# Altium schematic sheet main.SchDoc
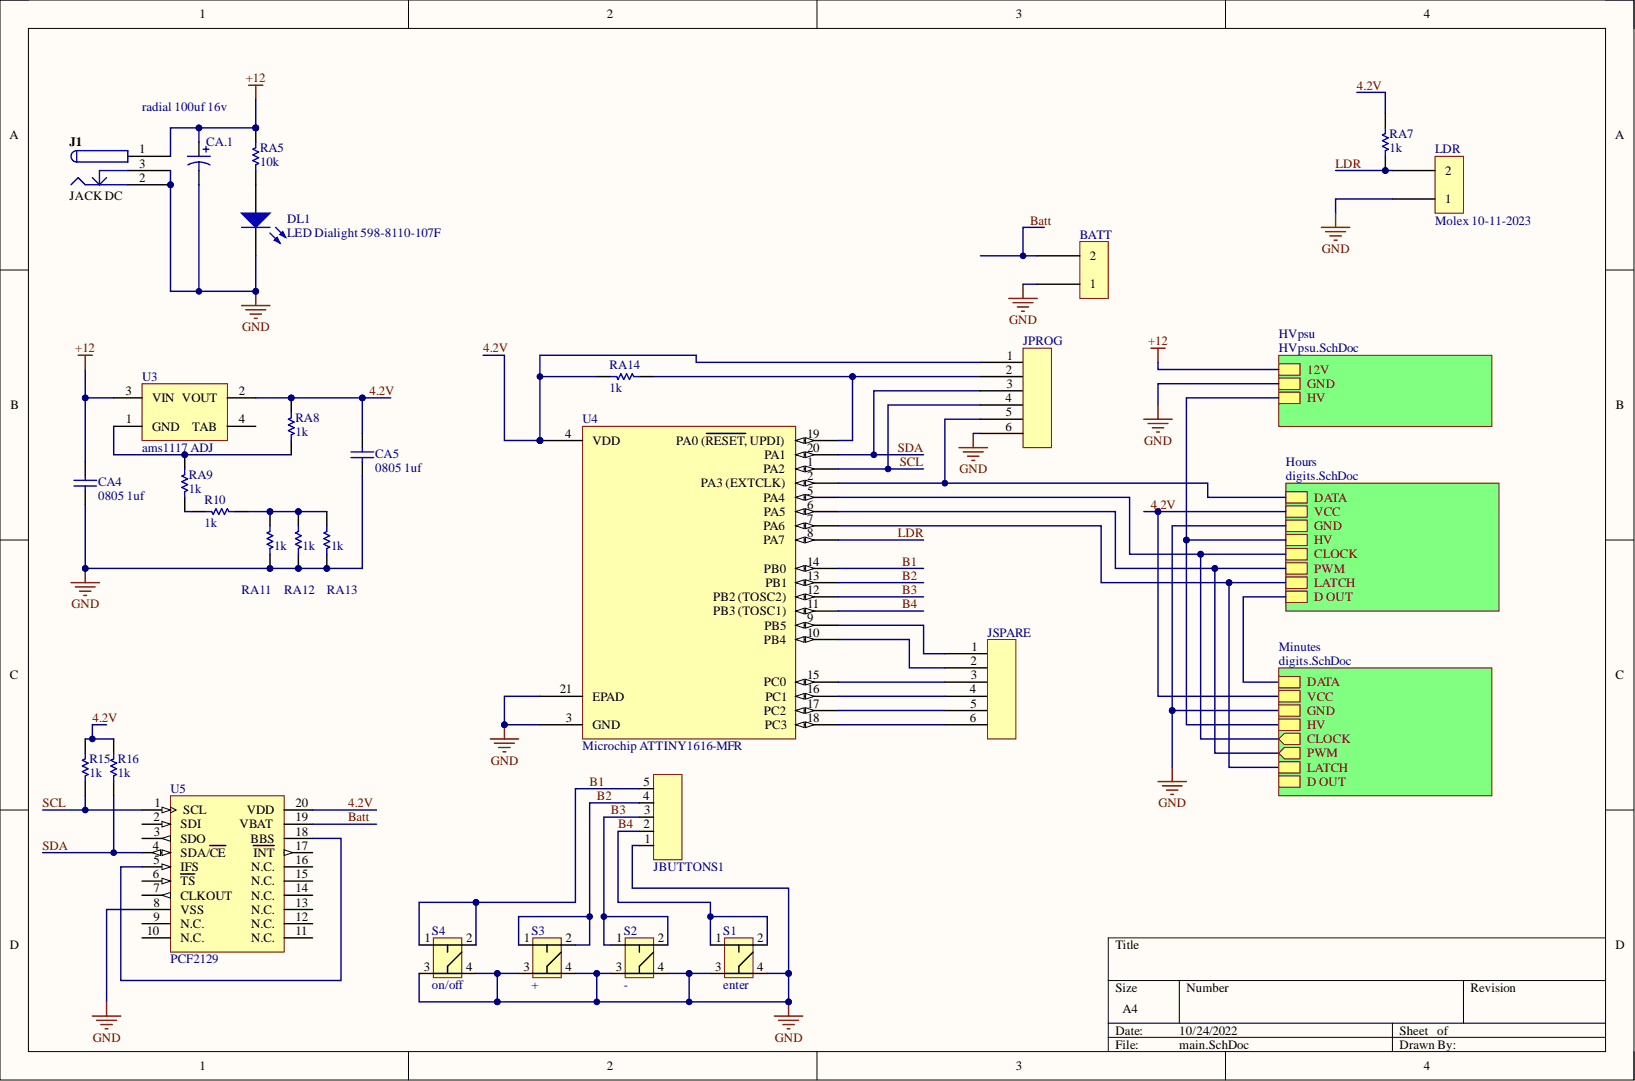
[diagram p0: main.SchDoc]

## Parts
BATT  Molex 10-11-2023  [PCB-hfmt5autxu6v8tocc2lw-1]
CA4  0805 1uf  Case/Package: 0805  [CAPC2013X140X50NL20T25]
CA5  0805 1uf  Case/Package: 0805  [CAPC2013X140X50NL20T25]
CA.1  radial 100uf 16v  [Capacitor]
DL1  LED Dialight 598-8110-107F  [PCB-9ifma0oh53wy532w7hco-1]
J1  JACK DC  [PCB-JACK-POWER]
JBUTTONS1  90147-1105  [PCB-igo8iybgws4dk1r37smx-1]  (value not drawn)
JPROG  Molex 70543-0005  [PCB-75wdbon8e5tdv2j637ia-1]  (value not drawn)
JSPARE  Molex 70543-0005  [PCB-75wdbon8e5tdv2j637ia-1]  (value not drawn)
LDR  Molex 10-11-2023  [PCB-hfmt5autxu6v8tocc2lw-1]
R10  1k  [PCB-hsw2o4davxo7exkzigz5-1]
R15  1k  [PCB-hsw2o4davxo7exkzigz5-1]
R16  1k  [PCB-hsw2o4davxo7exkzigz5-1]
RA5  10k  [PCB-hsw2o4davxo7exkzigz5-1]
RA7  1k  [PCB-hsw2o4davxo7exkzigz5-1]
RA8  1k  [PCB-hsw2o4davxo7exkzigz5-1]
RA9  1k  [PCB-hsw2o4davxo7exkzigz5-1]
RA11  1k  [PCB-hsw2o4davxo7exkzigz5-1]
RA12  1k  [PCB-hsw2o4davxo7exkzigz5-1]
RA13  1k  [PCB-hsw2o4davxo7exkzigz5-1]
RA14  1k  [PCB-hsw2o4davxo7exkzigz5-1]
S1  enter  [PCB-dfixreegix1guex256v0-1]
S2  -  [PCB-dfixreegix1guex256v0-1]
S3  +  [PCB-dfixreegix1guex256v0-1]
S4  on/off  [PCB-dfixreegix1guex256v0-1]
U3  ams1117 ADJ  [SOT-223_D]
U4  Microchip ATTINY1616-MFR  [PCB-l0aji733vjrxxdnx6zin-1]
U5  PCF2129  [SO20_N]

## Nets
+12: CA4.2 CA.1.1 J1.1 RA5.2 U3.3(VIN)
GND: BATT.1 CA4.1 CA5.1 CA.1.2 DL1.1 J1.2 J1.3 JBUTTONS1.1 JPROG.6 LDR.1 RA11.2 RA12.2 RA13.2 S1.3 S1.4 S2.3 S2.4 S3.3 S3.4 S4.3 S4.4 U4.3(GND) U4.21(EPAD) U5.8(VSS)
4.2V: CA5.2 JPROG.1 R15.2 R16.2 RA7.2 RA8.1 RA14.1 U3.2(VOUT) U4.4(VDD) U5.20(VDD)
B1: JBUTTONS1.5 S4.1 S4.2 U4.14(PB0)
B2: JBUTTONS1.4 S3.1 S3.2 U4.13(PB1)
B3: JBUTTONS1.3 S2.1 S2.2 U4.12(PB2_(TOSC2))
B4: JBUTTONS1.2 S1.1 S1.2 U4.11(PB3_(TOSC1))
Batt: BATT.2 U5.19(VBAT)
LDR: LDR.2 RA7.1 U4.8(PA7)
SCL: JPROG.4 R15.1 U4.1(PA2) U5.1(SCL)
SDA: JPROG.3 R16.1 U4.20(PA1) U5.4(SDA/CE)
NetDL1_2: DL1.2 RA5.1
NetJPROG_2: JPROG.2 RA14.2 U4.19(PA0_(RESET,_UPDI))
NetJPROG_5: JPROG.5 U4.2(PA3_(EXTCLK))
NetJSPARE_1: JSPARE.1 U4.9(PB5)
NetJSPARE_2: JSPARE.2 U4.10(PB4)
NetJSPARE_3: JSPARE.3 U4.15(PC0)
NetJSPARE_4: JSPARE.4 U4.16(PC1)
NetJSPARE_5: JSPARE.5 U4.17(PC2)
NetJSPARE_6: JSPARE.6 U4.18(PC3)
NetR10_1: R10.1 RA11.1 RA12.1 RA13.1
NetR10_2: R10.2 RA9.1
NetRA8_2: RA8.2 RA9.2 U3.1(GND)
NetU3_4: U3.4(TAB)
NetU4_5: U4.5(PA4)
NetU4_6: U4.6(PA5)
NetU4_7: U4.7(PA6)
NetU5_2: U5.2(SDI)
NetU5_3: U5.3(SDO)
NetU5_5: U5.5(IFS) U5.18(BBS)
NetU5_6: U5.6(TS)
NetU5_7: U5.7(CLKOUT)
NetU5_9: U5.9(N.C.)
NetU5_10: U5.10(N.C.)
NetU5_11: U5.11(N.C.)
NetU5_12: U5.12(N.C.)
NetU5_13: U5.13(N.C.)
NetU5_14: U5.14(N.C.)
NetU5_15: U5.15(N.C.)
NetU5_16: U5.16(N.C.)
NetU5_17: U5.17(INT)
D OUT:
HV:

## Library data of the parts
BATT LDR = 10-11-2023: 2.54mm (.100") Pitch KK® Crimp Terminal Housing, High Pressure, 2 Circuits; Component Kind: Standard; Library Name: Octopart library
CA4 CA5 = CMP-127269-2: CAP CER 1UF 10V 10% X7R 0805; Manufacturer Part Number: 0805ZC105KAZ2A; Capacitance: 1e-06 F; Case/Package (SI): 2012; Dielectric Material: Ceramic Multilayer; Lead-Free Status: Lead Free; Voltage Rating (DC): 10.0 V; Capacitance Tolerance: ±10%; Component Kind: Standard; Dielectric Characteristic: X7R; Library Name: CommunityVault; Mounting Style: Surface Mount; Number of Pins: 2; Octopart Url: https://octopart.com/0805zc105kaz2a-avx+interconnect+%2F+elco-195627; OctopartID: b6f47ffc6fb96933; REACH SVHC Compliance: No SVHC; RoHS: Compliant; Size-Height: 0.00094 m; Size-Length: 0.00201 m; Size-Width: 0.00125 m
CA.1 = ECA-1CM101: Cap Aluminum 100uF 16V 20% (5 X 11mm) Radial Aluminum Cylindrical Can 2mm 180mA 2000 hr 85°C Bulk; Voltage Rating (DC): 16.0 V; Component Kind: Standard; Library Name: Octopart library; Octopart URL: http://octopart.com/eca1cm101-panasonic-2628787; OctopartID: 48ba8198716f977d; Packaging: Bulk; REACH SVHC Compliance: No SVHC; Size-Diameter: 5.00 mm; Size-Length: 11.0 mm
DL1 = 598-8110-107F: 598-8110-107F, Dialight, SMD LED 0805 Package, Red Single color SOLD IN INCREMENTS OF 4000. (REEL); Lead-Free Status: Lead Free; Wavelength: 635 nm, 642 nm; Color: Red; Component Kind: Standard; Lens Color: Red; Library Name: Octopart library; Mounting Style: Surface Mount, Vertical; Number of Pins: 2; Octopart URL: https://octopart.com/598-8110-107f-dialight-868569; Size-Height: 700 µm; Size-Width: 1.25 mm
J1 = KLDX-0202-B: Conn Power PIN 2 POS Solder RA Thru-Hole 3 Terminal 1 Port; Current Rating: 3.50 A; Gender: Female; Voltage Rating (DC): 24.0 V; Color: Black; Component Kind: Standard; Library Name: Octopart library; Octopart URL: http://octopart.com/kldx-0202-b-kycon-765598; Packaging: Bag
JBUTTONS1 = 22-03-2051: Pin header Male 5; Number of Contacts: 5; Component Kind: Standard; Contact Material: Brass; Library Name: Octopart library
JPROG JSPARE = 70543-0005: Connector Shrouded Header 6 Position 2.54mm Solder Straight Thru-Hole Tube; Current Rating: 3.00 A; ELV Compliant: Compliant; Gender: Male; Glow Wire Compliant: Non-Compliant; Lead-Free Status: Lead Free; Number of Circuits: 5, 6; Number of Contacts: 6; Color: Black; Component Kind: Standard; Contact Material: Phosphor Bronze; Contact Plating: Tin, Gold; Halogen Free Status: Not Halogen Free; Library Name: Octopart library; Lifecycle Status: Active; Manufacturer Part Number: 70543-0005; Material: Brass; Mounting Style: Through Hole, Vertical; Number of Positions: 6; Number of Rows: 1; Octopart URL: https://octopart.com/70543-0005-molex-12981; OctopartID: 09cac0c01e19d60d; Operating Temperature: -40.0 °C to 105 °C; Orientation: Vertical; Packaging: Tube; REACH SVHC Compliance: No SVHC; RoHS: Compliant; Size-Height: 11.4 mm; Size-Length: 17.8 mm
R10 R15 R16 RA5 RA7-RA9 RA11-RA14 = RC0805FR-071KL: Res Thick Film 0805 1K Ohm 1% 1/8W ?100ppm/C Molded SMD SMD Paper T/R; Lead-Free Status: Lead Free; Power Rating: 125 mW; Temperature Coefficient: -100 ppm/°C to 100 ppm/°C; Voltage Rating (DC): 150 V; Case/Package: 0805; Component Kind: Standard; Library Name: Octopart library; Manufacturer Part Number: RC0805FR-071KL; Mounting Style: Surface Mount; Number of Pins: 2; OctopartID: 420a8962dabf9dcd; Operating Temperature: 155 °C (max); Packaging: Tape & Reel; REACH SVHC Compliance: No SVHC; Size-Length: 2.00 mm; Size-Width: 1.25 mm
S1-S4 = PTS645SK432LFS: Switch Tactile N.O SPST Round Button PC Pins 0.05A 12VDC 100000Cycle 2.55N Thru-Hole Bulk; Component Kind: Standard; Library Name: Octopart library
U3 = LD1117STR: LD1117 Series 1300 mA Adjustable Output Voltage LDO Regulator - SOT-223; Dropout Voltage: 1.10 V; Input Voltage (DC): 10.0 V (max); Lead-Free Status: Lead Free; Number of Outputs: 1; Output Current: 800 mA; Output Voltage: 1.25 V (min); Case/Package: SOT-223; Component Kind: Standard; Library Name: Octopart library; Lifecycle Status: Active; Manufacturer Part Number: LD1117STR; Mounting Style: Surface Mount; Number of Pins: 3; Octopart Url: http://octopart.com/ld1117str-stmicroelectronics-39592047; OctopartID: 36e7fdb133eee920; Packaging: Tape & Reel (TR), Cut Tape (CT); REACH SVHC Compliance: No SVHC; RoHS: Compliant; Seller Landing  Url: https://octopart-clicks.com/click/track?country=US&ak=8157f166&sig=00d8822&sid=1106&ppid=39592047&vpid=119747643&ct=offers
U4 = ATTINY1616-MFR: MCU 8-Bit ATTINY1616 AVR RISC 16KB Flash 2.5V/3.3V/5V 20-Pin VQFN T/R; Component Kind: Standard; Library Name: Octopart library
U5 = PCF2129AT/2,518: Timer IC t- real time clock NXP Semiconductors PCF2129AT/2, 518 Clock/calendar SO 20; Component Kind: Standard; Library Name: Octopart library; Lifecycle Status: Active; Manufacturer Part Number: PCF2129AT/2,518; RoHS: Compliant
